# Altium schematic sheet NVK6114.SchDoc
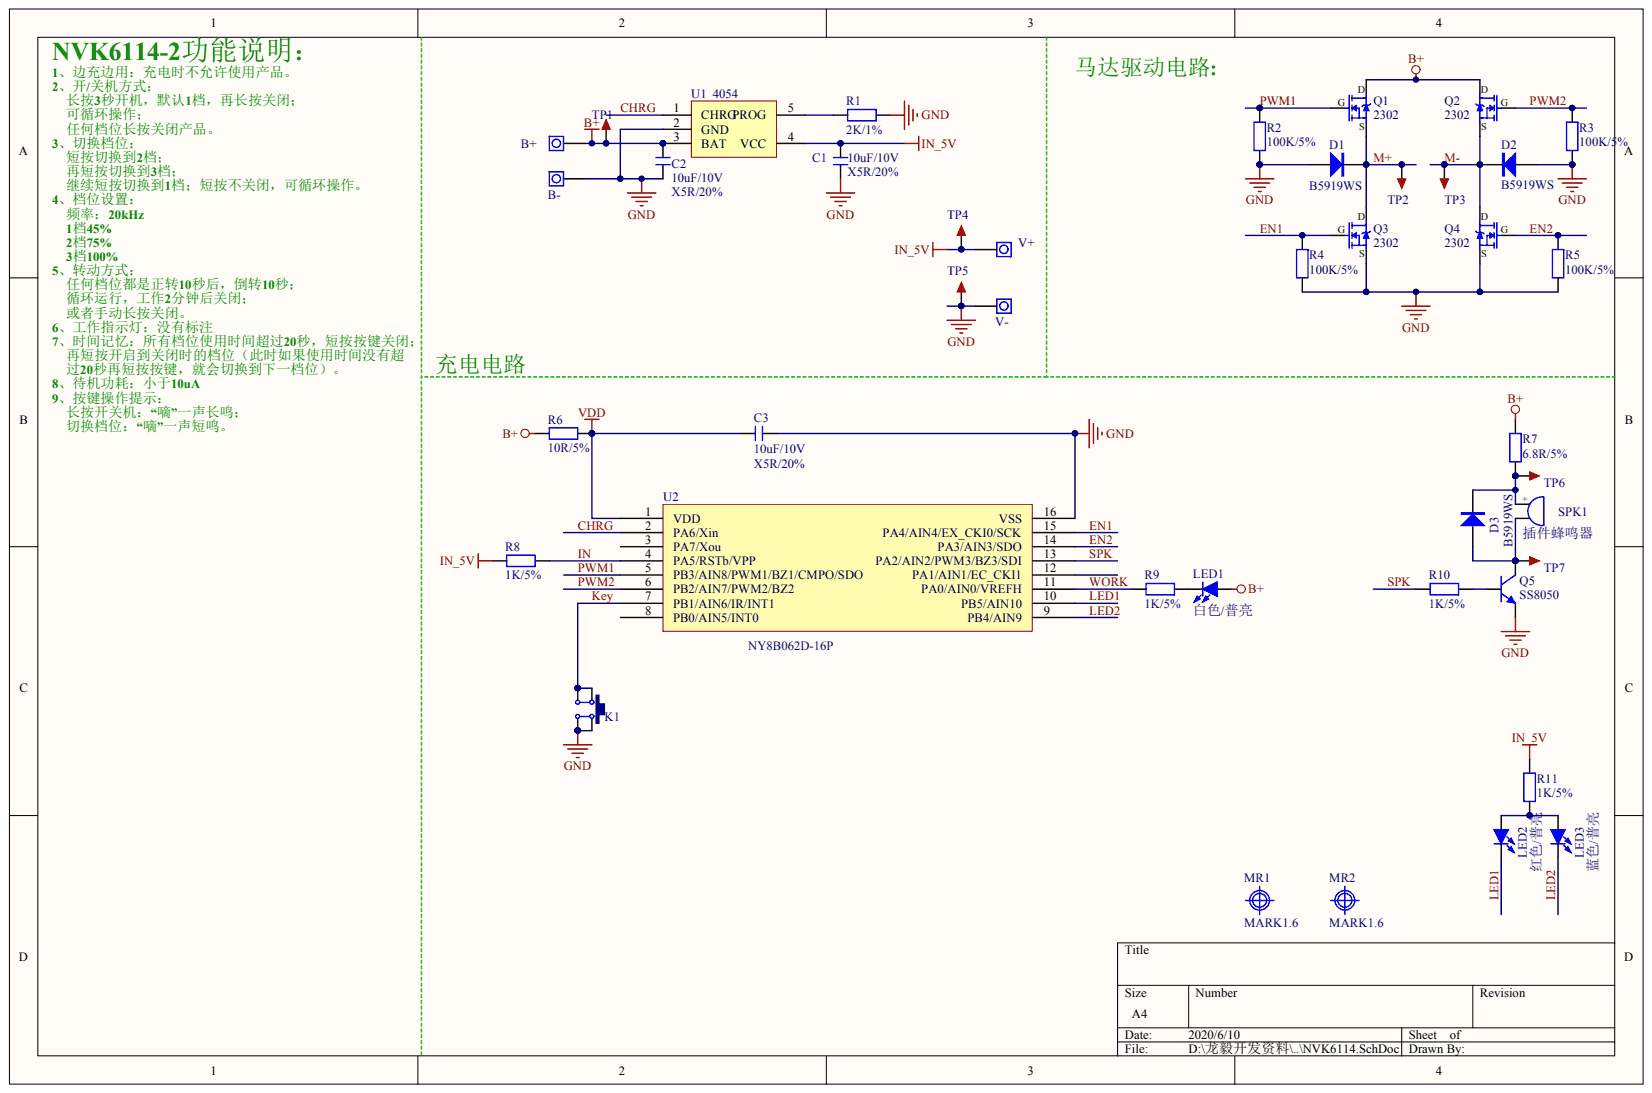
[diagram p0: NVK6114.SchDoc]

## Parts
C1  10uF/10V  材质: X5R/20%
C2  10uF/10V  材质: X5R/20%
C3  10uF/10V  材质: X5R/20%
D1  B5919WS
D2  B5919WS
D3  B5919WS
H1  B+
H2  B-
H3  V+
H4  V-
K1  4x4x2.5mm/立式  (value not drawn)
LED1  白色/普亮
LED2  红色/普亮
LED3  蓝色/普亮
MR1  MARK1.6
MR2  MARK1.6
Q1  2302
Q2  2302
Q3  2302
Q4  2302
Q5  SS8050
R1  2K/1%
R2  100K/5%
R3  100K/5%
R4  100K/5%
R5  100K/5%
R6  10R/5%
R7  6.8R/5%
R8  1K/5%
R9  1K/5%
R10  1K/5%
R11  1K/5%
SPK1  插件蜂鸣器
TP1  TEST  (value not drawn)
TP2  TEST  (value not drawn)
TP3  TEST  (value not drawn)
TP4  TEST  (value not drawn)
TP5  TEST  (value not drawn)
TP6  TEST  (value not drawn)
TP7  TEST  (value not drawn)
U1  4054
U2  NY8B062D-16P

## Nets
B+: C2.1 H1.1 LED1.1 Q1.3 Q2.3 R6.2 R7.2 TP1.1 U1.3(BAT)
GND: C1.1 C2.2 C3.1 D1.1 D2.1 H2.1 H4.1 K1.2 K1.3 Q3.2 Q4.2 Q5.2 R1.2 R2.1 R3.1 R4.1 R5.1 TP5.1 U1.2(GND) U2.16(VSS)
IN_5V: C1.2 H3.1 R8.1 R11.1 TP4.1 U1.4(VCC)
VDD: C3.2 R6.1 U2.1(VDD)
CHRG: U1.1(CHRG) U2.2(PA6/Xin)
EN1: Q3.1 R4.2 U2.15(PA4/AIN4/EX_CKI0/SCK)
EN2: Q4.1 R5.2 U2.14(PA3/AIN3/SDO)
IN: R8.2 U2.4(PA5/RSTb/VPP)
KEY: K1.1 K1.4 U2.7(PB1/AIN6/IR/INT1)
LED1: LED2.2 U2.10(PB5/AIN10)
LED2: LED3.2 U2.9(PB4/AIN9)
M+: D1.2 Q1.2 Q3.3 TP2.1
M-: D2.2 Q2.2 Q4.3 TP3.1
PWM1: Q1.1 R2.2 U2.5(PB3/AIN8/PWM1/BZ1/CMPO/SDO)
PWM2: Q2.1 R3.2 U2.6(PB2/AIN7/PWM2/BZ2)
SPK: R10.1 U2.13(PA2/AIN2/PWM3/BZ3/SDI)
WORK: R9.1 U2.11(PA0/AIN0/VREFH)
NetD3_1: D3.1 Q5.3 SPK1.2 TP7.1
NetD3_2: D3.2 R7.1 SPK1.1 TP6.1
NetLED1_2: LED1.2 R9.2
NetLED2_1: LED2.1 LED3.1 R11.2
NetQ5_1: Q5.1 R10.2
NetR1_1: R1.1 U1.5(PROG)

Not connected: U2 3(PA7/Xou) 8(PB0/AIN5/INT0) 12(PA1/AIN1/EC_CKI1)

## Library data of the parts
C1-C3 = (no symbol name): BOM名称: 无极性贴片电容; 版权归属: 源创客; 学习资源: www.ooocke.com
D1-D3 = (no symbol name): BOM名称: 肖特基二极管; 版权归属: 源创客; 属性: 40V/2A; 学习资源: www.ooocke.com
H1-H4 = (no symbol name): BOM名称: 引线焊盘; 版权归属: 源创客; 学习资源: www.ooocke.com
K1 = (no symbol name): BOM名称: 防水轻触开关; 版权归属: 源创客; 学习资源: www.ooocke.com
LED1-LED3 = (no symbol name): BOM名称: 贴片LED; 版权归属: 源创客; 学习资源: www.ooocke.com
MR1 MR2 = (no symbol name): BOM名称: 光学定位点; 版权归属: 源创客; 学习资源: www.ooocke.com
Q1-Q4 = (no symbol name): BOM名称: 场效应管; 版权归属: 源创客; 描述: N沟道 30V/4.2A; 学习资源: www.ooocke.com
Q5 = (no symbol name): BOM名称: 高频放大-NPN型; 版权归属: 源创客; 属性: 40V 1.5A 1W 100MHZ; 学习资源: www.ooocke.com
R1-R11 = (no symbol name): BOM名称: 贴片电阻; 版权归属: 源创客; 学习资源: www.ooocke.com
SPK1 = (no symbol name): BOM名称: 蜂鸣器; 版权归属: 源创客; 学习资源: www.ooocke.com
TP1-TP7 = (no symbol name): BOM名称: 测试点; 版权归属: 源创客; 学习资源: www.ooocke.com
U1 = (no symbol name): BOM名称: 锂电池充电芯片; 版权归属: 源创客; 学习资源: www.ooocke.com
